# Altium schematic sheet 2.WIZ750SR-110_IP101GRI.SchDoc
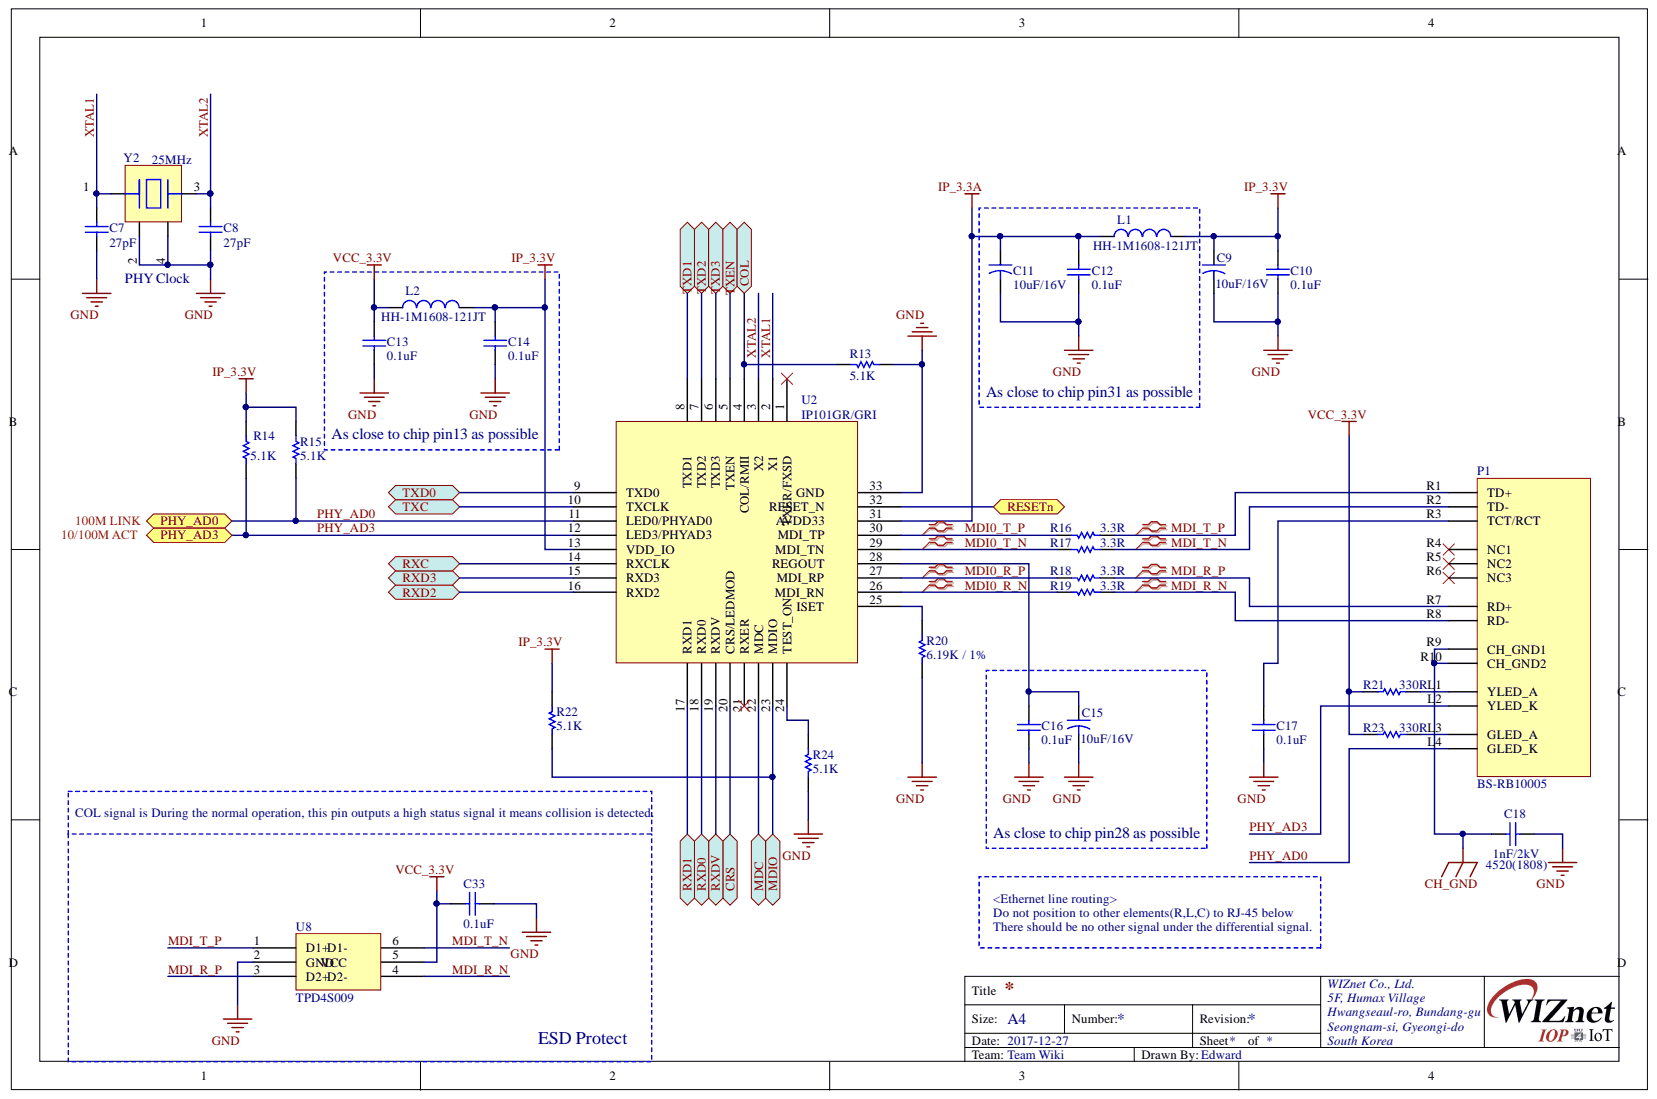
[diagram p0: 2.WIZ750SR-110_IP101GRI.SchDoc]

## Parts
C7  27pF  [CAP-0603]
C8  27pF  [CAP-0603]
C9  10uF/16V  [CAP-A Size]
C10  0.1uF  [CAP-0603]
C11  10uF/16V  [CAP-A Size]
C12  0.1uF  [CAP-0603]
C13  0.1uF  [CAP-0603]
C14  0.1uF  [CAP-0603]
C15  10uF/16V  [CAP-A Size]
C16  0.1uF  [CAP-0603]
C17  0.1uF  [CAP-0603]
C18  1nF/2kV  [CAP-1808]
C33  0.1uF  [CAP-0603]
L1  HH-1M1608-121JT  [IND-0603]
L2  HH-1M1608-121JT  [IND-0603]
P1  BS-RB10005  [RJ45-RB10005]
R13  5.1K  [RES-0603]
R14  5.1K  [RES-0603]
R15  5.1K  [RES-0603]
R16  3.3R  [RES-0603]
R17  3.3R  [RES-0603]
R18  3.3R  [RES-0603]
R19  3.3R  [RES-0603]
R20  6.19K / 1%  [RES-0603]
R21  330R  [RES-0603]
R22  5.1K  [RES-0603]
R23  330R  [RES-0603]
R24  5.1K  [RES-0603]
U2  IP101GR/GRI  [QFN32(0.4/4X4)]
U8  TPD4S009  [SOT-23-6L]
Y2  25MHz  [OSC-82J0626]

## Ports
COL, CRS, MDC, MDIO, PHY_AD0, PHY_AD3, RESETN, RXC, RXD0, RXD1, RXD2, RXD3, RXDV, TXC, TXD0, TXD1, TXD2, TXD3, TXEN

## Nets
CH_GND: C18.2 P1.R9(CH_GND1) P1.R10(CH_GND2)
GND: C7.1 C8.1 C9.2 C10.1 C11.2 C12.1 C13.1 C14.2 C15.2 C16.1 C17.1 C18.1 C33.2 R13.1 R20.1 R24.1 U2.33 U8.2(GND) Y2.2 Y2.4
IP_3.3A: C11.1 C12.2 L1.1 U2.31
IP_3.3V: C9.1 C10.2 C14.1 L1.2 L2.2 R14.1 R15.1 R22.2 U2.13(VDD_IO)
VCC_3.3V: C13.2 C33.1 L2.1 R21.1 R23.1 U8.5
COL: R13.2 U2.4 ; port COL
CRS: U2.20(CRS/LEDMOD) ; port CRS
MDC: U2.22(MDC) ; port MDC
MDI0_R_N: R19.2 U2.26
MDI0_R_P: R18.2 U2.27
MDI0_T_N: R17.2 U2.29
MDI0_T_P: R16.2 U2.30
MDI_R_N: P1.R8(RD-) R19.1 U8.4
MDI_R_P: P1.R7(RD+) R18.1 U8.3(D2+)
MDI_T_N: P1.R2(TD-) R17.1 U8.6
MDI_T_P: P1.R1(TD+) R16.1 U8.1(D1+)
MDIO: R22.1 U2.23(MDIO) ; port MDIO
PHY_AD0: P1.L4(GLED_K) R15.2 U2.11(LED0/PHYAD0) ; port PHY_AD0
PHY_AD3: P1.L2(YLED_K) R14.2 U2.12(LED3/PHYAD3) ; port PHY_AD3
RXC: U2.14(RXCLK) ; port RXC
RXD0: U2.18(RXD0) ; port RXD0
RXD1: U2.17(RXD1) ; port RXD1
RXD2: U2.16(RXD2) ; port RXD2
RXD3: U2.15(RXD3) ; port RXD3
RXDV: U2.19(RXDV) ; port RXDV
TXC: U2.10(TXCLK) ; port TXC
TXD0: U2.9(TXD0) ; port TXD0
TXD1: U2.8 ; port TXD1
TXD2: U2.7 ; port TXD2
TXD3: U2.6 ; port TXD3
TXEN: U2.5 ; port TXEN
XTAL1: C7.2 U2.2 Y2.1
XTAL2: C8.2 U2.3 Y2.3
NetC15_1: C15.1 C16.2 U2.28
NetC17_2: C17.2 P1.R3(TCT/RCT)
NetP1_L1: P1.L1(YLED_A) R21.2
NetP1_L3: P1.L3(GLED_A) R23.2
NetR20_2: R20.2 U2.25
NetR24_2: R24.2 U2.24(TEST_ON)
NetU2_32: U2.32 ; port RESETN

Not connected: P1 R4(NC1) R5(NC2) R6(NC3); U2 1 21(RXER)

## Library data of the parts
C7 C8 C10 C12-C14 C16 C17 C33 = CAP-0603: Ceramic CAP 0603(1608); Component Kind: Standard; Library Name: Scott_lib.IntLib
C9 C11 C15 = CAP-A Size: Tantla CAP A Size EIA/IECQ 3216; Component Kind: Standard; Library Name: Scott_lib.IntLib
C18 = CAP-1808: Ceramic CAP 1808(4520); Component Kind: Standard; Library Name: Scott_lib.IntLib
L1 L2 = IND-0603: Inductor 0603(1608); Component Kind: Standard; Library Name: Scott_lib.IntLib
P1 = RB1-125BAG1A: 10/100 BASE-TX MAGJACK; Component Kind: Standard; Library Name: Edward.IntLib
R13-R24 = RES-0603: Component Kind: Standard; Description: RES-0603; Library Name: Scott_lib.IntLib
U2 = IP101GR/GRI: Pakage: VQFN-32; Component Kind: Standard; Part_name: IP101GR/GRI; Library Name: Edward.IntLib
U8 = tpd4s009: 6-PIN SOT DBVor DCK Package; Component Kind: Standard; Library Name: tpd4s009_SOT.SchLib
Y2 = OSC-82J0626-25MHz: X-tal 25MHz,3.2x2.5 SMD, CL=18pF; Component Kind: Standard; Library Name: Scott_lib.IntLib
